# Altium schematic sheet Sheet2.SchDoc(Sheet2)
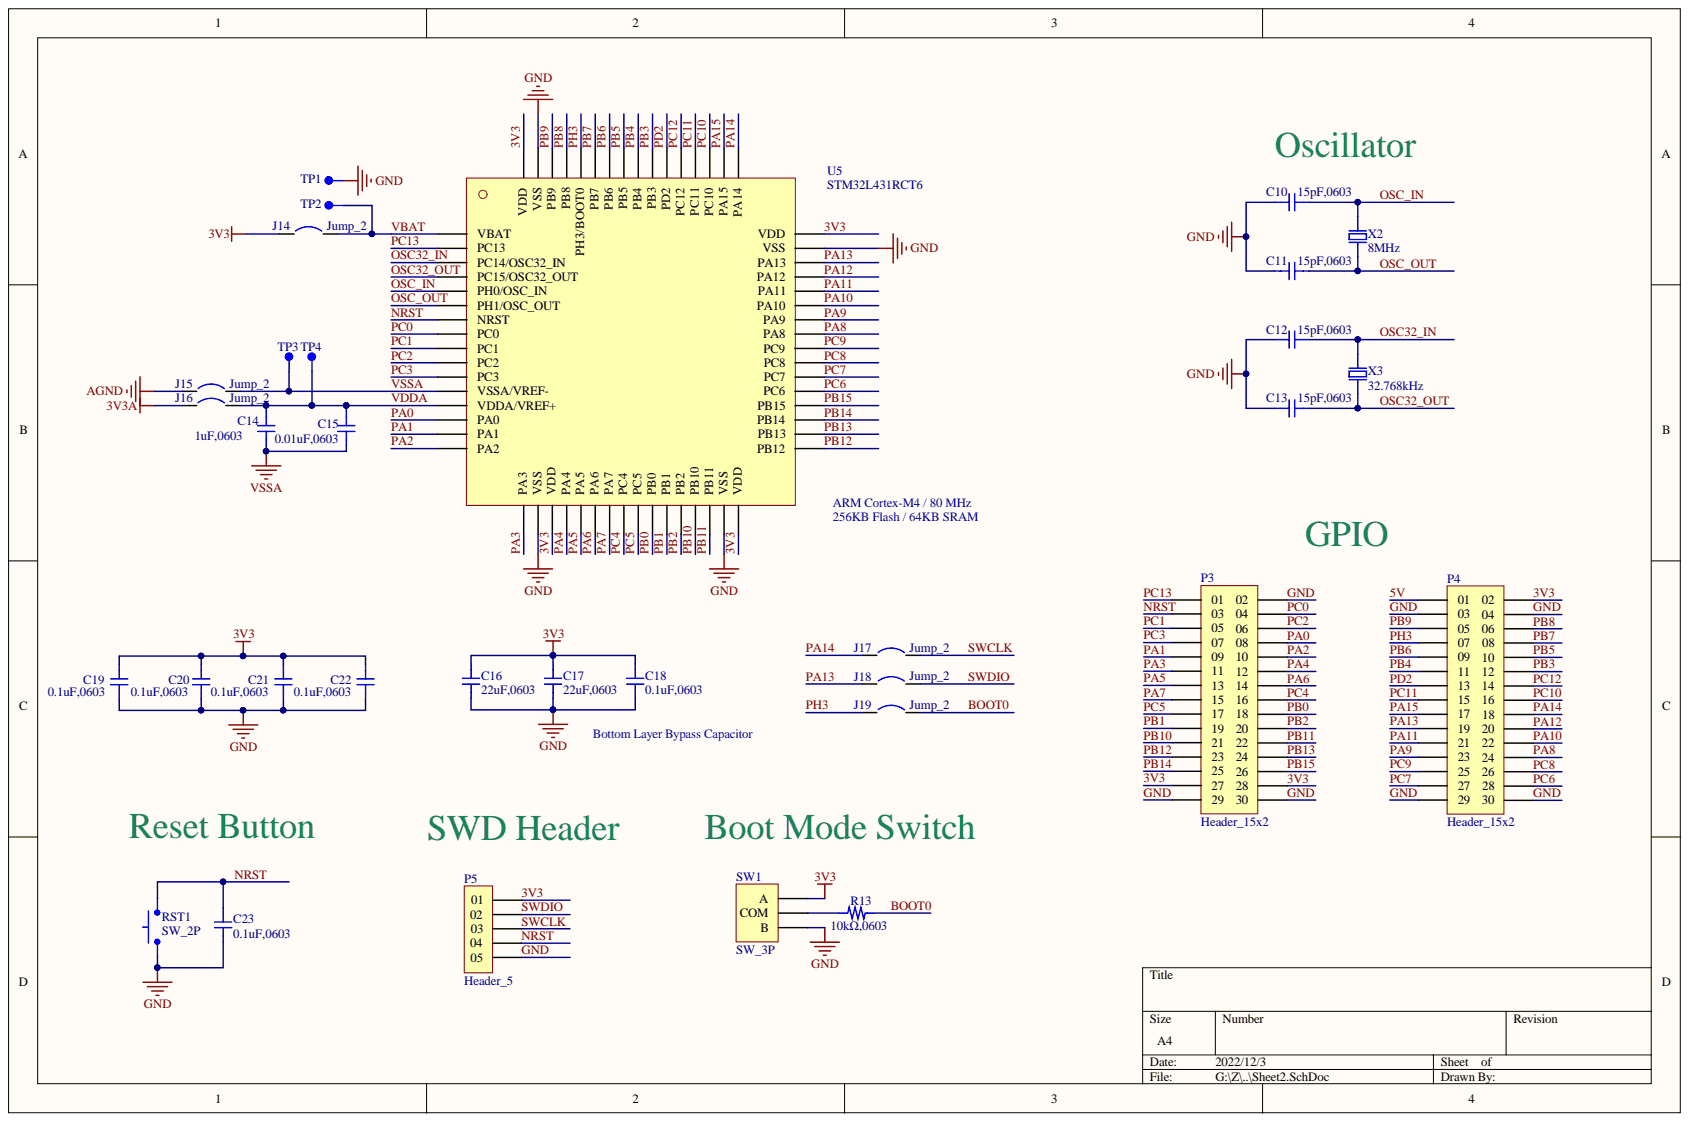
[diagram p0: Sheet2.SchDoc]

## Parts
C10  15pF,0603  [C0603]
C11  15pF,0603  [C0603]
C12  15pF,0603  [C0603]
C13  15pF,0603  [C0603]
C14  1uF,0603  [C0603]
C15  0.01uF,0603  [C0603]
C16  22uF,0603  [C0603]
C17  22uF,0603  [C0603]
C18  0.1uF,0603  [C0603]
C19  0.1uF,0603  [C0603]
C20  0.1uF,0603  [C0603]
C21  0.1uF,0603  [C0603]
C22  0.1uF,0603  [C0603]
C23  0.1uF,0603  [C0603]
J14  Jump_2  [Jp_small]
J15  Jump_2  [Jp_small]
J16  Jump_2  [Jp_small]
J17  Jump_2  [Jp_small]
J18  Jump_2  [Jp_small]
J19  Jump_2  [Jp_small]
P3  Header_15x2  [Header_15x2_s]
P4  Header_15x2  [Header_15x2_s]
P5  Header_5  [Header_5]
R13  10kΩ,0603  [R0603]
RST1  SW_2P  [SW_2P_3]
SW1  SW_3P  [SW]
TP1  TP  [TP_1.5x1.5mm]
TP2  TP  [TP_1.5x1.5mm]
TP3  TP  [TP_1.5x1.5mm]
TP4  TP  [TP_1.5x1.5mm]
U5  STM32L431RCT6  [LQFP_64]
X2  8MHz  [XTAL_SMD_3225]
X3  32.768kHz  [XTAL_32.768KHz]

## Nets
3V3A: J16.01
AGND: J15.01
3V3: C16.02 C17.02 C18.02 C19.02 C20.02 C21.02 C22.02 J14.01 P3.27 P3.28 P4.02 P5.01 SW1.01 U5.19(VDD) U5.32(VDD) U5.48(VDD) U5.64(VDD)
5V: P4.01
BOOT0: J19.02 R13.01
GND: C10.02 C11.02 C12.02 C13.02 C16.01 C17.01 C18.01 C19.01 C20.01 C21.01 C22.01 C23.01 P3.02 P3.29 P3.30 P4.03 P4.04 P4.29 P4.30 P5.05 RST1.01 SW1.03 TP1.01 U5.18(VSS) U5.31(VSS) U5.47(VSS) U5.63(VSS)
NRST: C23.02 P3.03 P5.04 RST1.02 U5.07(NRST)
OSC32_IN: C12.01 U5.03(PC14/OSC32_IN) X3.02
OSC32_OUT: C13.01 U5.04(PC15/OSC32_OUT) X3.01
OSC_IN: C10.01 U5.05(PH0/OSC_IN) X2.02
OSC_OUT: C11.01 U5.06(PH1/OSC_OUT) X2.01
PA0: P3.08 U5.14(PA0)
PA1: P3.09 U5.15(PA1)
PA2: P3.10 U5.16(PA2)
PA3: P3.11 U5.17(PA3)
PA4: P3.12 U5.20(PA4)
PA5: P3.13 U5.21(PA5)
PA6: P3.14 U5.22(PA6)
PA7: P3.15 U5.23(PA7)
PA8: P4.24 U5.41(PA8)
PA9: P4.23 U5.42(PA9)
PA10: P4.22 U5.43(PA10)
PA11: P4.21 U5.44(PA11)
PA12: P4.20 U5.45(PA12)
PA13: J18.01 P4.19 U5.46(PA13)
PA14: J17.01 P4.18 U5.49(PA14)
PA15: P4.17 U5.50(PA15)
PB0: P3.18 U5.26(PB0)
PB1: P3.19 U5.27(PB1)
PB2: P3.20 U5.28(PB2)
PB3: P4.12 U5.55(PB3)
PB4: P4.11 U5.56(PB4)
PB5: P4.10 U5.57(PB5)
PB6: P4.09 U5.58(PB6)
PB7: P4.08 U5.59(PB7)
PB8: P4.06 U5.61(PB8)
PB9: P4.05 U5.62(PB9)
PB10: P3.21 U5.29(PB10)
PB11: P3.22 U5.30(PB11)
PB12: P3.23 U5.33(PB12)
PB13: P3.24 U5.34(PB13)
PB14: P3.25 U5.35(PB14)
PB15: P3.26 U5.36(PB15)
PC0: P3.04 U5.08(PC0)
PC1: P3.05 U5.09(PC1)
PC2: P3.06 U5.10(PC2)
PC3: P3.07 U5.11(PC3)
PC4: P3.16 U5.24(PC4)
PC5: P3.17 U5.25(PC5)
PC6: P4.28 U5.37(PC6)
PC7: P4.27 U5.38(PC7)
PC8: P4.26 U5.39(PC8)
PC9: P4.25 U5.40(PC9)
PC10: P4.16 U5.51(PC10)
PC11: P4.15 U5.52(PC11)
PC12: P4.14 U5.53(PC12)
PC13: P3.01 U5.02(PC13)
PD2: P4.13 U5.54(PD2)
PH3: J19.01 P4.07 U5.60(PH3/BOOT0)
SWCLK: J17.02 P5.03
SWDIO: J18.02 P5.02
VBAT: J14.02 TP2.01 U5.01(VBAT)
VDDA: C14.02 C15.02 J16.02 TP4.01 U5.13(VDDA/VREF+)
VSSA: C14.01 C15.01 J15.02 TP3.01 U5.12(VSSA/VREF-)
NetR13_02: R13.02 SW1.02

## Library data of the parts
C10-C23 = Cap: Component Kind: Standard; Library Name: myLib1.IntLib
R13 = Res: Component Kind: Standard; Library Name: myLib1.IntLib
X2 X3 = XTAL: Component Kind: Standard; Library Name: myLib1.IntLib
J14-J19 = Jump_2: Component Kind: Standard; Library Name: myLib1.IntLib
P3 P4 = Header_15x2: Component Kind: Standard; Library Name: myLib1.IntLib
P5 = Header_5: Component Kind: Standard; Library Name: myLib1.IntLib
RST1 = SW_2P: Component Kind: Standard; Library Name: myLib1.IntLib
SW1 = SW_3P: Component Kind: Standard; Library Name: myLib1.IntLib
TP1-TP4 = TP: Component Kind: Standard; Library Name: myLib1.IntLib
U5 = STM32L431RCT6: Component Kind: Standard; Library Name: myLib1.IntLib
